# Altium schematic sheet BLE.SchDoc(BLE)
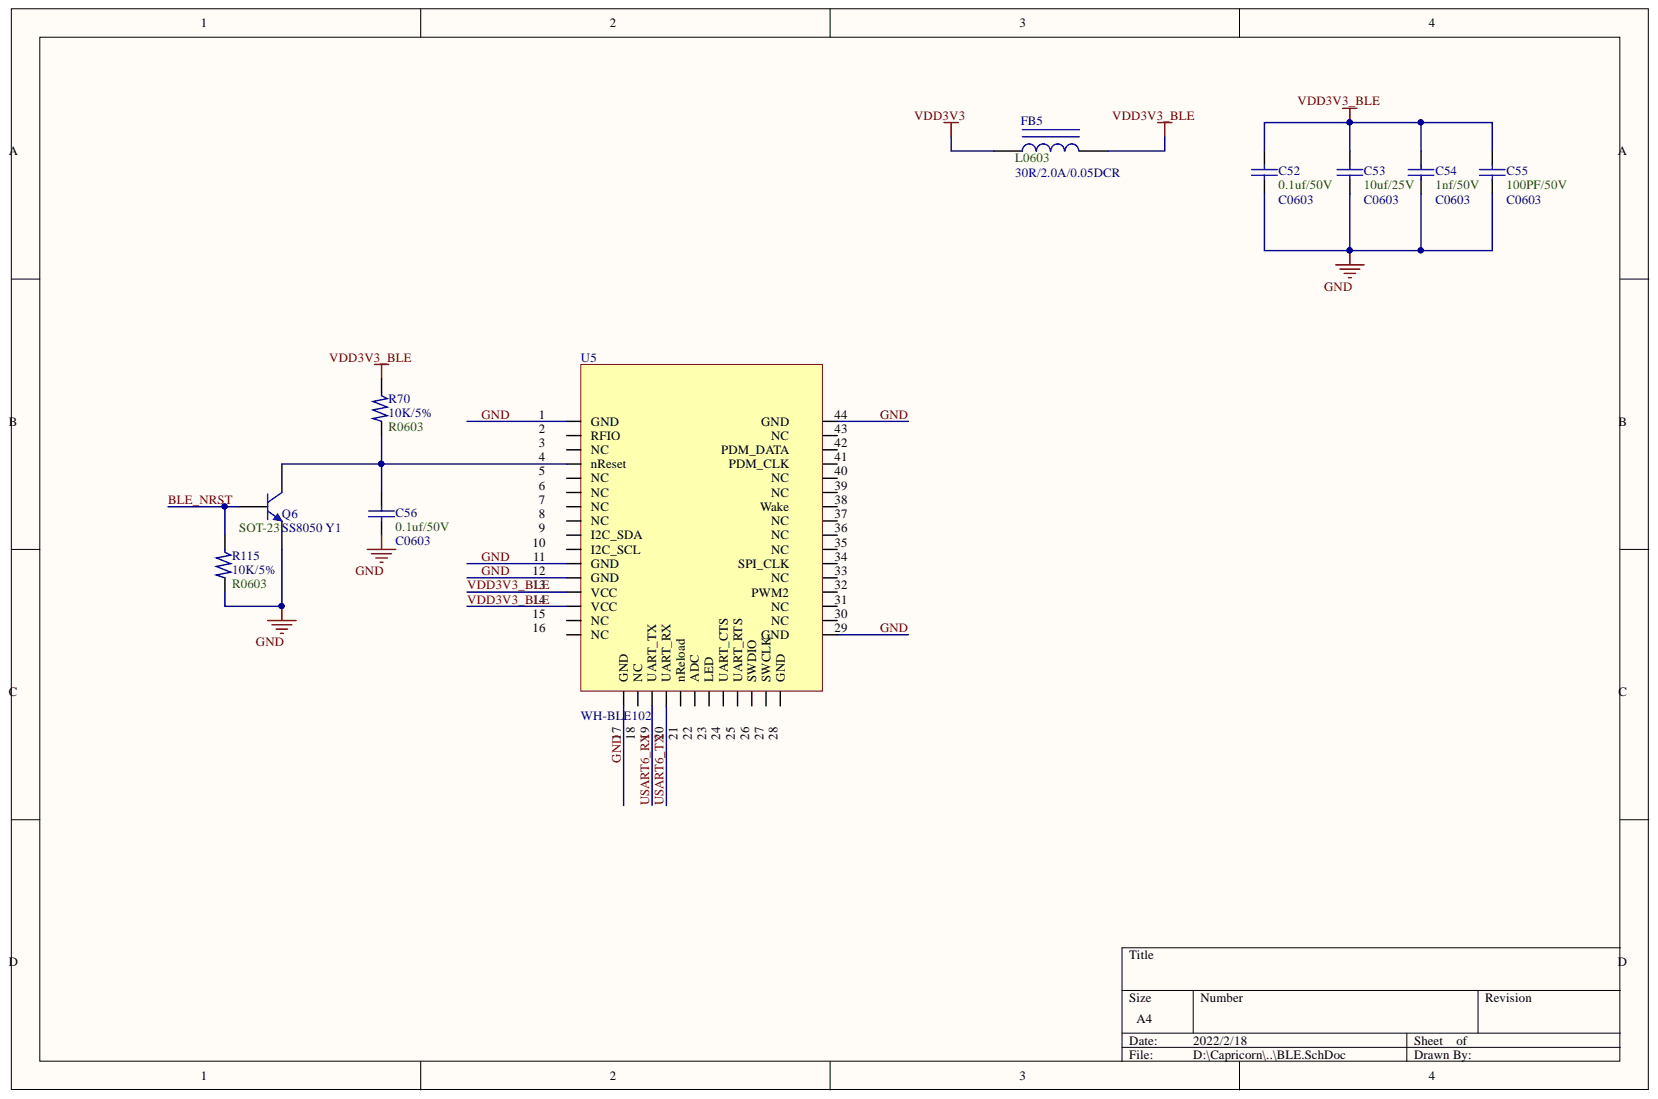
[diagram p0: BLE.SchDoc]

## Parts
C52  0.1uf/50V  value C0603  [0603_C]
C53  10uf/25V  value C0603  [0603_C]
C54  1nf/50V  value C0603  [0603_C]
C55  100PF/50V  value C0603  [0603_C]
C56  0.1uf/50V  value C0603  [0603_C]
FB5  30R/2.0A/0.05DCR  Package: L0603  [0603_L]
Q6  SS8050 Y1  Package: SOT-23  [SOT-23(SOT-23-3)]
R70  10K/5%  [R0603]
R115  10K/5%  [R0603]
U5  WH-BLE102  [WH-BLE102-V1.1]

## Nets
VDD3V3: FB5.1
BLE_NRST: Q6.1 R115.2
GND: C52.2 C53.2 C54.2 C55.2 C56.2 Q6.2 R115.1 U5.1(GND) U5.11(GND) U5.12(GND) U5.17(GND) U5.29 U5.44
USART6_RX: U5.19(UART_TX)
USART6_TX: U5.20(UART_RX)
VDD3V3_BLE: C52.1 C53.1 C54.1 C55.1 FB5.2 R70.2 U5.13(VCC) U5.14(VCC)
NetC56_1: C56.1 Q6.3 R70.1 U5.4(nReset)

Not connected: U5 2(RFIO) 3(NC) 5(NC) 6(NC) 7(NC) 8(NC) 9(I2C_SDA) 10(I2C_SCL) 15(NC) 16(NC) 18(NC) 21(nReload) 22(ADC) 23(LED) 24(UART_CTS) 25(UART_RTS) 26(SWDIO) 27(SWCLK) 28(GND) 30 31 32 33 34 35 36 37 38 39 40 41 42 43

## Library data of the parts
C52-C56 = CAP: Capacitor; Component Kind: Standard
FB5 = 0603 3.9nH 0.3nH: 贴片电感; Component Kind: Standard; ComponentLink1Description: 供应商链接; ComponentLink1URL: http://www.szlcsc.com/product/details_14473.html; Library Name: Miscellaneous Devices LC.IntLib; Supplier: LC; SuppliersPartNumber: C13818
Q6 = SOT-23 SS8050 Y1: 三极管; Component Kind: Standard; ComponentLink1Description: 供应商链接; ComponentLink1URL: http://www.szlcsc.com/product/details_2507.html; Library Name: Miscellaneous Devices LC.IntLib; Supplier: LC; SuppliersPartNumber: C2150
R70 R115 = 电阻: Component Kind: Standard
U5 = WH-BLE102: Component Kind: Standard; Library Name: M4.SchLib
